# Altium schematic sheet schematic.SchDoc
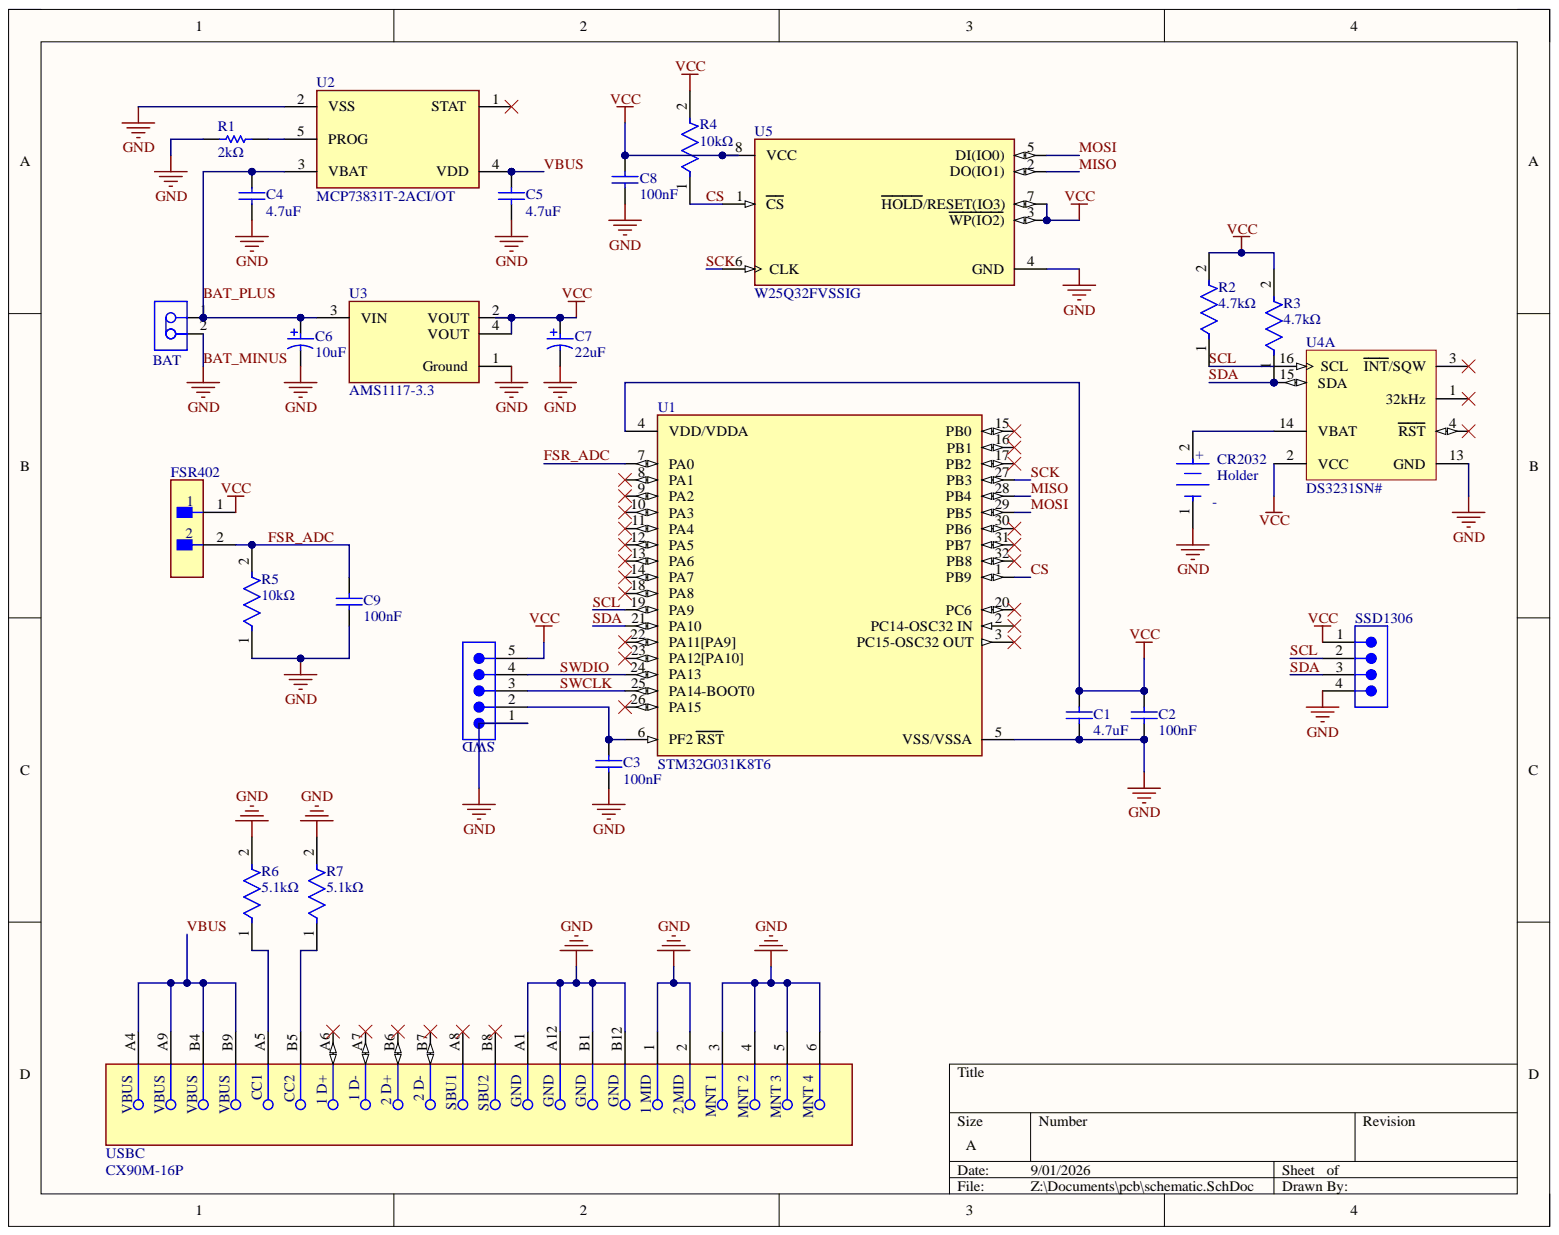
[diagram p0: schematic.SchDoc]

## Parts
BAT  B2B-PH-K-S(LF)(SN)  [JST-B2B-PH-K-S_V]  (value not drawn)
C1  4.7uF  [CAPC1608X90X35ML15T15]
C2  100nF  [CAPC1608X87X35LL15T15]
C3  100nF  [CAPC1608X87X35LL15T15]
C4  4.7uF  [CAPC1608X90X35ML15T15]
C5  4.7uF  [CAPC1608X90X35ML15T15]
C6  10uF  [KEMT-T322-2_V]
C7  22uF  [KEMT-T491-B_L]
C8  100nF  [CAPC1608X87X35LL15T15]
C9  100nF  [CAPC1608X87X35LL15T15]
CR2032  Holder  [FP-1775485-1-MFG]
FSR402  (no value)  [FP-B02B-WPJSS-1_LF_SN-MFG]
R1  2kΩ  [RESC1608X55X25LL10T15]
R2  4.7kΩ  [FP-RMCF0603-IPC_B]
R3  4.7kΩ  [FP-RMCF0603-IPC_B]
R4  10kΩ  [FP-AC0603-IPC_A]
R5  10kΩ  [FP-AC0603-IPC_A]
R6  5.1kΩ  [FP-MCR03-IPC_B]
R7  5.1kΩ  [FP-MCR03-IPC_B]
SSD1306  691317710004  [691317710004]  (value not drawn)
SWD  691305330005  [691305330005]  (value not drawn)
U1  STM32G031K8T6  [FP-LQFP32-5V-MFG]
U2  MCP73831T-2ACI/OT  [FP-C04-091-OT-IPC_B]
U3  AMS1117-3.3  [FP-AMS1117-IPC_C]
U4  DS3231SN#  [W16#H2_L]  (part A)
U5  W25Q32FVSSIG  [FP-SS-MFG]
USBC  CX90M-16P  [FP-CX90M-16P-MFG]

## Nets
VCC: C1.2 C2.2 C7.1 C8.2 FSR402.1 R2.2 R3.2 R4.2 SSD1306.1 SWD.5 U1.4(VDD/VDDA) U3.2(VOUT) U3.4(VOUT) U4.2(VCC) U5.3(WP(IO2)) U5.7(HOLD/RESET(IO3)) U5.8(VCC)
BAT_MINUS: BAT.2 C1.1 C2.1 C3.2 C4.1 C5.1 C6.2 C7.2 C8.1 C9.1 CR2032.1 R1.1 R5.1 R6.2 R7.2 SSD1306.4 SWD.1 U1.5(VSS/VSSA) U2.2(VSS) U3.1(Ground) U4.13(GND) U5.4(GND) USBC.1 USBC.2 USBC.3 USBC.4 USBC.5 USBC.6 USBC.A1 USBC.A12 USBC.B1 USBC.B12
BAT_PLUS: BAT.1 C4.2 C6.1 U2.3(VBAT) U3.3(VIN)
CS: R4.1 U1.1(PB9) U5.1(CS)
FSR_ADC: C9.2 FSR402.2 R5.2 U1.7(PA0)
MISO: U1.28(PB4) U5.2(DO(IO1))
MOSI: U1.29(PB5) U5.5(DI(IO0))
SCK: U1.27(PB3) U5.6(CLK)
SCL: R2.1 SSD1306.2 U1.19(PA9) U4.16(SCL)
SDA: R3.1 SSD1306.3 U1.21(PA10) U4.15(SDA)
SWCLK: SWD.3 U1.25(PA14-BOOT0)
SWDIO: SWD.4 U1.24(PA13)
VBUS: C5.2 U2.4(VDD) USBC.A4 USBC.A9 USBC.B4 USBC.B9
NetC3_1: C3.1 SWD.2 U1.6(PF2_RST)
NetCR2032_2: CR2032.2 U4.14(VBAT)
NetR1_2: R1.2 U2.5(PROG)
NetR6_1: R6.1 USBC.A5
NetR7_1: R7.1 USBC.B5

Not connected: U1 2(PC14-OSC32_IN) 3(PC15-OSC32_OUT) 8(PA1) 9(PA2) 10(PA3) 11(PA4) 12(PA5) 13(PA6) 14(PA7) 15(PB0) 16(PB1) 17(PB2) 18(PA8) 20(PC6) 22(PA11[PA9]) 23(PA12[PA10]) 26(PA15) 30(PB6) 31(PB7) 32(PB8); U2 1(STAT); U4 1(32kHz) 3(INT/SQW) 4(RST); USBC A6 A7 A8 B6 B7 B8

## Library data of the parts
BAT = CMP-2000-05162-1: Male Header, Pitch 2 mm, 1 x 2 Position, Height 6 mm, Tail Length 3.4 mm, -25 to 85 degC, RoHS, Bulk; Manufacturer: JST; No of Positions: 2; Pitch: 2mm; Style/Orientation: StraightHeader/Plug; Component Kind: Standard; Datasheet URL: http://datasheet.octopart.com/B2B-PH-K-S%28LF%29%28SN%29-JST-datasheet-8324864.pdf; Datasheet Version: Nov-08; Library Name: Altium Content Vault; Manufacturer URL: http://www.jst.com/home2.html; Termination: Crimp; Type: ShroudedHeader
C1 C4 C5 = CMP-2006-02288-1: C0603C475K9PAC7411; Manufacturer: Kemet; Component Kind: Standard; Library Name: Altium Content Vault; Part Number: C0603C475K9PAC7411
C2 C3 C8 C9 = CMP-2006-03843-1: C0603T104K3RACTU; Manufacturer: Kemet; Component Kind: Standard; Library Name: Altium Content Vault; Part Number: C0603T104K3RACTU
C6 = CMP-2000-05177-1: T322C106K025AT; Manufacturer: Kemet; Brand/Series: T322Series; Capacitance: 10μF; Configuration: Axial; Material, Element: Tantalum; Tolerance: -10,+10%; Voltage, Rating: 25VDC; Component Kind: Standard; Datasheet URL: http://download.siliconexpert.com/pdfs/2005/12/01/pemco_a/4/kmt/capacitors/f3100etamoldaxrad.pdf; Datasheet Version: Oct-05; Library Name: Altium Content Vault; Manufacturer URL: http://www.kemet.com/; Mounting Type: PCB; Termination: Through-hole
C7 = CMP-2000-07193-1: T491B226K010AT; Manufacturer: Kemet; Capacitance: 22000000pF; Case Style: Molded; DCL: 2.2uA; DF: 6%; ESR: 2.4ohm; Insulation: NotRequired; Lead Spacing (nom): NotRequiredmm; Polarity: Polar; Product Depth (mm): 2.8mm; Product Height (mm): 1.9mm; Product Length (mm): 3.5mm; Rad Hardened: No; Tolerance (+ or -): 10%; Voltage: 10VDC; Case Code: B; Component Kind: Standard; Construction: SMTChip; Datasheet URL: http://capacitoredge.kemet.com/capedge2/DataSheet/Datasheet-T491B226K010AT.pdf?pn=T491B226K010AT; Datasheet Version: 10/2016; Failure Rate: NotRequired; Length W/Weld: NotRequiredmm; Length W/Weld (max): NotRequiredmm; Library Name: Altium Content Vault; Manufacturer URL: http://www.kemet.com/; Mounting Style: SurfaceMount; Mounting Technology: SurfaceMount; Operating Temp Range: -55Cto125C; Package / Case: 3528-21; Package Description: 2-Pin Surface Mount Device, Body 3.5 x 2.8 mm; Package Reference: T491-B; Packaging: TapeandReel; Product Diameter (mm): NotRequiredmm; Seal: NotRequired; Seated Plane Height: NotRequiredmm; Wire Form: NotRequired
CR2032 = CMP-03355-000025-1: BATT HLDR COIN 20MM 1 CEL PC PIN; Color: Black; Material: Nylon; Number of Contacts: 2; Number of Ports: 1; Component Kind: Standard; Contact Material: Stainless Steel; ELV: Compliant; Housing Color: Black; Housing Material: Nylon; Library Name: Altium Content Vault; Mount: Through Hole; Number of Positions: 2; Number of Terminals/Pins: 2; Orientation: Straight; Package Quantity: 80; Packaging: Tray; Radiation Hardening: No; RoHS Compliant: Yes; Termination: Solder
FSR402 = CMP-17439-000657-1: CONN HEADER VERT 2POS 5MM; Current Rating: 7A; Gender: Male; Mated Stacking Heights: 25.3mm; Number of Contacts: 2; Ratings: Waterproof; Voltage Rating: 300V; Wire/Cable Gauge: 18AWG; Color: Natural; Component Kind: Standard; Connector Type: Header; Contact Finish - Mating: TIN; Contact Material: Copper Alloy; Contact Plating: Tin; Contact Shape: Square; Contact Type: Male Pin; Fastening Type: Latch; Flammability Rating: UL94 V-0; Housing Material: PBT; Insulation Color: Natural; Insulation Material: PBT; Lead Free: Lead Free; Lead Pitch: 5mm; Library Name: Altium Content Vault; Max Operating Temperature: 85°C; Min Operating Temperature: -25°C; Mount: Through Hole; Number of Positions: 2; Number of Rows: 1; Orientation: Straight; Packaging: Bulk; Pitch: 5mm; Reach SVHC Compliant: Yes; Series: WPJ; Termination: Solder; Voltage Rating (AC): 300V
R1 = CMP-2000-04183-1: RC0603FR-102KL; Manufacturer: Yageo; Component Kind: Standard; Library Name: Altium Content Vault; Part Number: RC0603FR-102KL
R2 R3 = CMP-26527-004068-1: RMCF0603FG4K70; Max Power Dissipation: 100mW; Resistance: 4.7kR; Temperature Coefficient: 100ppm/°C; Tolerance: 1%; Case/Package: 0603; Component Kind: Standard; Features: Automotive AEC-Q200; Height: 0.022inch; Length: 0.061inch; Library Name: Altium Content Vault; Max Operating Temperature: 155°C; Min Operating Temperature: -55°C; Mount: Surface Mount; Number of Terminations: 2; Packaging: Tape and Reel; Resistor Type: Thick Film; RoHS Compliant: Yes; Series: RMCF; Width: 0.031inch
R4 R5 = CMP-03412-040683-1: RES 10kΩ 5.00% 0.2W 0603; Component Kind: Standard; Library Name: Altium Content Vault
R6 R7 = CMP-08839-027005-1: MCR03EZPJ512; Max Power Dissipation: 100mW; Resistance: 5.1kR; Temperature Coefficient: 200ppm/°C; Tolerance: 5%; Voltage Rating (DC): 50V; Case Code (Imperial): 0603; Case Code (Metric): 1608; Case/Package: 0603; Component Kind: Standard; Contact Plating: Tin; Features: Automotive AEC-Q200; Height: 0.022inch; Lead Free: Lead Free; Length: 0.063inch; Library Name: Altium Content Vault; Max Operating Temperature: 155°C; Min Operating Temperature: -55°C; Mount: Surface Mount; Number of Terminations: 2; Package Quantity: 5000; Packaging: Tape and Reel; Power Rating: 100mW; Resistor Type: Thick Film; RoHS Compliant: Yes; Series: MCR; Technology: THICK FILM; Width: 0.031inch; Working Voltage: 50V
SSD1306 = CMP-1502-02058-3: Terminal Block, 5.00MM Pitch, Close Horizontal Pcb Header, Flanges, 4 Pins; Component Kind: Standard; Library Name: Altium Content Vault
SWD = CMP-1502-01818-3: Terminal Block, Header, 5Pos, Th Rohs Compliant: Yes; Gender: Male; Component Kind: Standard; Lead Pitch: 3.81mm; Library Name: Altium Content Vault; Number of Pins: 5; Packaging: Box
U1 = CMP-12105-000118-1: IC MCU 32BIT 64KB FLASH 32LQFP; Component Kind: Standard; Library Name: Altium Content Vault
U2 = CMP-0133-00143-2: IC CONTROLLR LI-ION 4.2V SOT23-5; Drain to Source Resistance: 350mR; Max Charge Current: 500mA; Max Input Voltage: 6V; Max Output Voltage: 4.5V; Max Power Dissipation: 1.54W; Min Output Voltage: 4.168V; Min Supply Voltage: 3.75V; Number of Cells: 1; Voltage Rating: 4.534V; Case/Package: SOT-23; Component Kind: Standard; Contact Plating: Tin; Height: 0.051inch; Lead Free: Lead Free; Length: 0.122inch; Library Name: Altium Content Vault; Max Operating Temperature: 85°C; Max Supply Voltage: 6V; Min Operating Temperature: -40°C; Mount: Surface Mount; Number of Channels: 1; Number of Outputs: 1; Number of Pins: 5; Output Current: 500mA; Output Voltage: 4.2V; Packaging: Tape and Reel; Radiation Hardening: No; REACH SVHC: No SVHC; Termination: SMD/SMT; Weight: 0.00709oz; Width: 0.071inch
U3 = CMP-209535-000001-1: LDO Voltage Regulators 1A, 3.3V; Manufacturer: Advanced Monolithic Systems; Dropout Voltage: 1.1V; Max Junction Temp: +125°C; Max Power Dissipation: 1.2W; Max Supply Voltage: 15V; Nominal Supply Current: 5mA; Number Of Outputs: 1; Output Type: Fixed; Standoff Height: 0.64mm; Automotive: No; Category: IC; Component Kind: Standard; ComponentLink2Description: Datasheet; ComponentLink2URL: http://www.advanced-monolithic.com/pdf/ds1117.pdf; Device Class L1: Integrated Circuits (ICs); Device Class L2: Power Management ICs; Device Class L3: Voltage Regulators - Linear; Height: 1.8mm; Ipc Land Pattern Name: SOT229P700X180-4; Lead Free: Yes; Library Name: Altium Content Vault; Manufacturer Part Number: AMS1117-3.3; Octopart: https://octopart.com/ams1117-3.3-advanced+monolithic+systems-25899300; Output Current: 1A; Output Voltage: 3.3V; Package: AMS1117; RoHS: TRUE; Temperature: -40°C to +125°C; Temperature Range High: +125°C; Temperature Range Low: -40°C
U4 = CMP-0254-00293-2: Extremely Accurate I2C-Integrated Real-Time Clock/TCXO/Crystal, 16-Pin SO, -40 to 85 degC, RoHS Qualified; Code_IPC: SOIC127P1030-16; Code_JEDEC: MS-013-AA; Component Kind: Standard; ComponentLink1Description: Manufacturer URL; ComponentLink1URL: http://www.maxim-ic.com; ComponentLink2Description: Datasheet; ComponentLink2URL: http://datasheets.maxim-ic.com/en/ds/DS3231.pdf; ComponentLink3Description: Package Specification; ComponentLink3URL: http://pdfserv.maxim-ic.com/package_dwgs/21-0042.PDF; DatasheetVersion: Rev 8, Jul-2010; Library Name: Altium Content Vault; PackageDescription: 16-Pin Small-Outline IC, Wide (0.3in), 1.27 mm Pitch, SOIC (W), RoHS Qualified; PackageReference: W16#H2; PackageVersion: revD, 2010
U5 = CMP-2000-05006-2: IC FLASH 32M SPI 104MHZ 8SOIC; Manufacturer: Winbond; Obsolete Part: true; Access Time: 7ns; Interface: SPI,Other; Max Supply Voltage: 3.6V; Memory Size: 32Mbits; Min Supply Voltage: 2.7V; Nominal Supply Current: 8-20mA; Standoff Height: 0.05mm; Ambient Temperature Range High: +85°C; Ambient Temperature Range Low: -40°C; Automotive: No; Category: IC; Component Kind: Standard; ComponentLink2Description: Datasheet; ComponentLink2URL: https://www.winbond.com/resource-files/w25q32fv%20revj%2006032016.pdf; Device Class L1: Integrated Circuits (ICs); Device Class L2: Memory; Device Class L3: Flash; Digikey Description: IC FLASH 32M SPI 104MHZ 8SOIC; Footprint Url: http://www.winbond.com/resource-files/an0000009%20spiflash%20pcb%20layout%20guideline%20v2.0%2009252017.pdf?__locale=en; Frequency: 104MHz; Height: 2.16mm; Ipc Land Pattern Name: SOIC127P790X195-8; Lead Free: Yes; Library Name: Altium Content Vault; Manufacturer Part Number: W25Q32FVSSIG; Memory Type: FLASH; Octopart: https://octopart.com/w25q32fvssig-winbond-23896462; Package: SS; RoHS: TRUE; Temperature: -40°C to +85°C; Temperature Range High: +85°C; Temperature Range Low: -40°C
USBC = CMP-11601-000005-1: CONN RCPT USB2.0 TYPEC 16POS SMD; Connector Type: USB; Current Rating: 1.25A; Gender: Receptacle; Mating Cycles: 10000; Number of Contacts: 16; Number of Ports: 1; Shielding: Shielded; Component Kind: Standard; Contact Plating: Gold; Flammability Rating: UL94 V-0; Insulation Color: Black; Library Name: Altium Content Vault; Max Operating Temperature: 85°C; Min Operating Temperature: -30°C; Mount: Surface Mount; Packaging: Cut Tape; Termination: Solder
